# Altium schematic sheet AIM2_v0.9_LTE.SchDoc
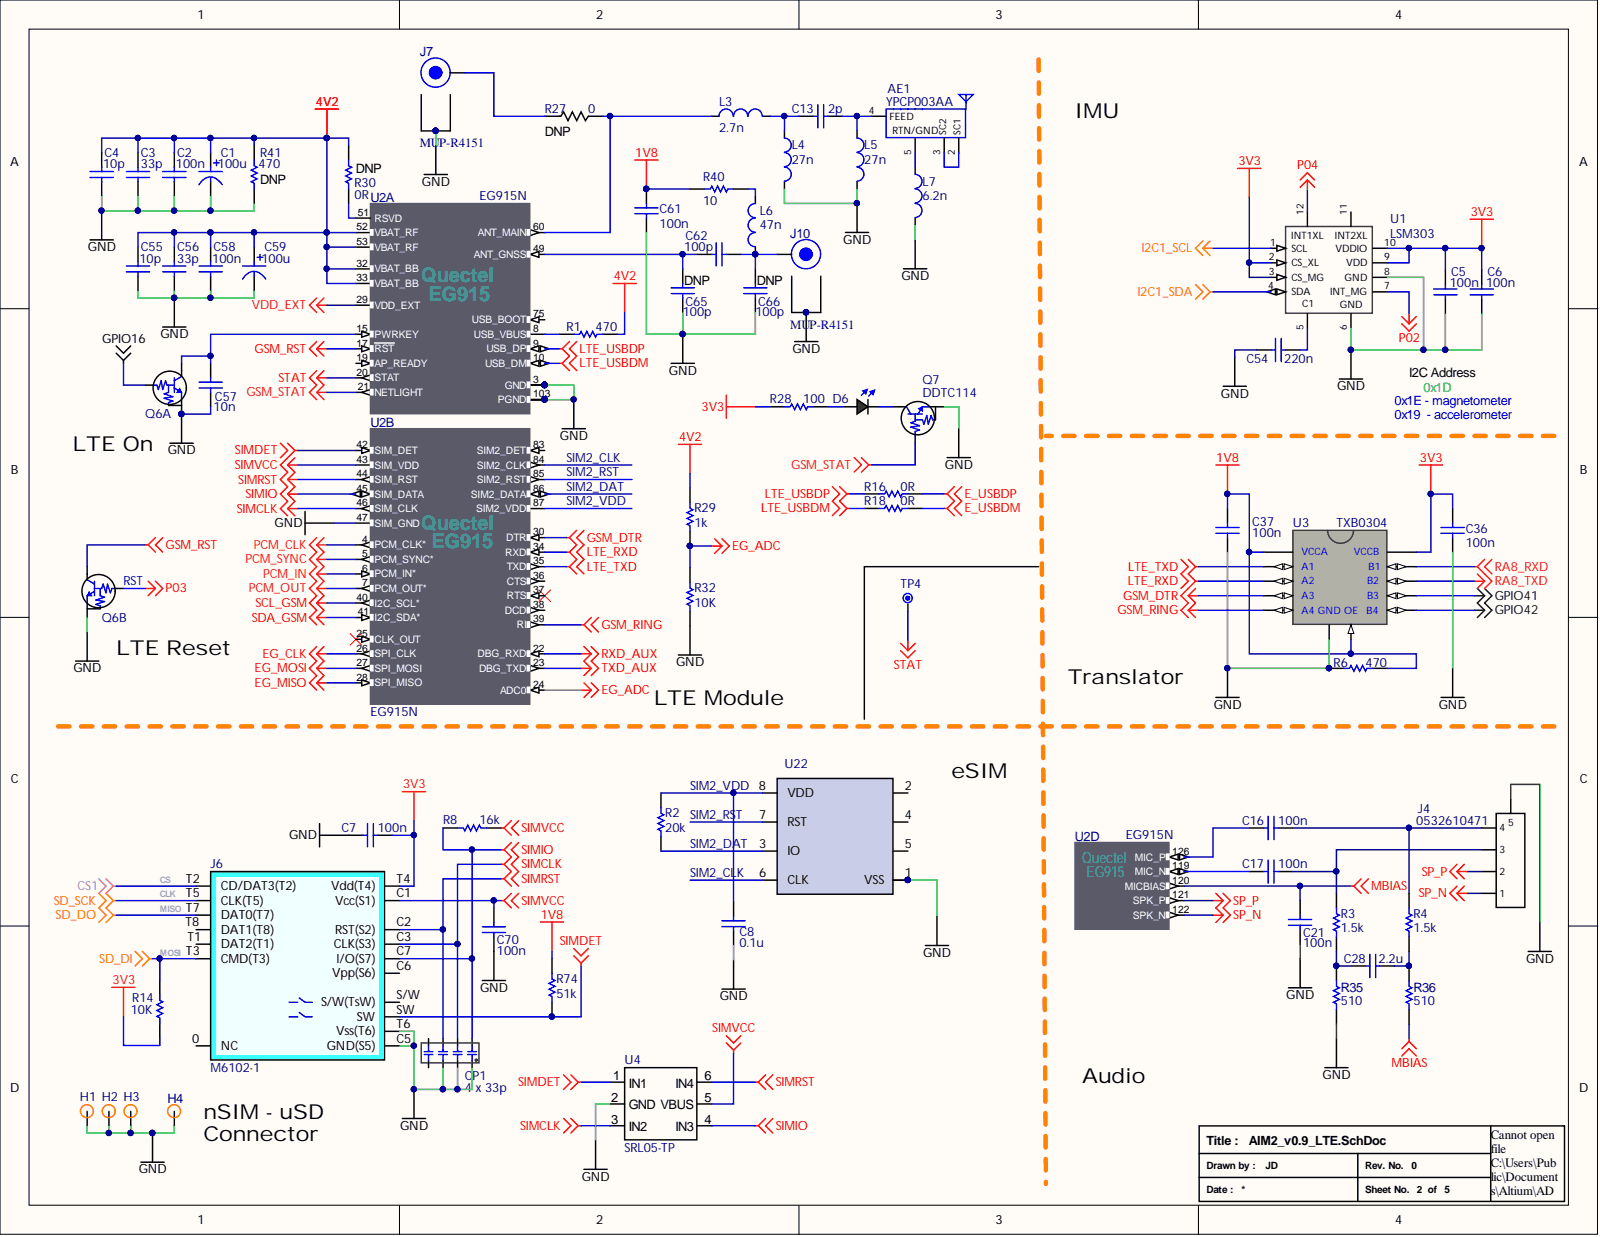
[diagram p0: AIM2_v0.9_LTE.SchDoc]

## Parts
AE1  YPCP003AA  [Quectel_YPCP003AA]
C1  100u  [Cap_1210_TAN]
C2  100n  [CAP_0402]
C3  33p  [CAP_0402]
C4  10p  [CAP_0402]
C5  100n  [CAP_0402]
C6  100n  [CAP_0402]
C7  100n  [CAP_0402]
C8  0.1u  [CAP_0402_ghost]
C13  2p  [CAP_0402]
C16  100n  [CAP_0402_ghost]
C17  100n  [CAP_0402_ghost]
C21  100n  [CAP_0402_ghost]
C28  2.2u  [CAP_0402_ghost]
C36  100n  [CAP_0402]
C37  100n  [CAP_0402]
C54  220n  [CAP_0402]
C55  10p  [CAP_0402]
C56  33p  [CAP_0402]
C57  10n  [CAP_0402]
C58  100n  [CAP_0402]
C59  100u  [Cap_1210_TAN]
C61  100n  [CAP_0402]
C62  100p  [CAP_0402]
C65  100p  [CAP_0402_ghost]
C66  100p  [CAP_0402_ghost]
C70  100n  [CAP_0402]
CP1  4 x 33p  [NCap_0805]
D6  LED_570nm(green), 2.2V,20mA,0603  [LED-0603-GREEN]  (value not drawn)
H1  2.5mm_Via  [2.5_Via]  (value not drawn)
H2  2.5mm_Via  [2.5_Via]  (value not drawn)
H3  2.5mm_Via  [2.5_Via]  (value not drawn)
H4  3.73mm_Hole_6.2mmD  [3.73mm_Hole_6.2mmD]  (value not drawn)
J4  0532610471  [Molex_Pblade_53261-0471_ghost]
J6  M6102-1  [MUP_M6102-1]
J7  MUP-R4151  [UFL_MUP_R4151]
J10  MUP-R4151  [UFL_MUP_R4151]
L3  2.7n  [IND_0402]
L4  27n  [IND_0402]
L5  27n  [IND_0402]
L6  47n  [IND_0402]
L7  6.2n  [IND_0402]
Q6  DMC56404  [SOT_363]  (value not drawn)  (parts A,B)
Q7  DDTC114  [SOT323]
R1  470  [RES_0402]
R2  20k  [RES_0402_ghost]
R3  1.5k  [RES_0402_ghost]
R4  1.5k  [RES_0402_ghost]
R6  470  [RES_0402]
R8  16k  [RES_0402]
R14  10K  [RES_0402]
R16  0R  [RES_0402]
R18  0R  [RES_0402]
R27  0  [RES_0400_ghost]
R28  100  [RES_0402]
R29  1k  [RES_0402]
R30  0R  [RES_0402_ghost]
R32  10K  [RES_0402]
R35  510  [RES_0402_ghost]
R36  510  [RES_0402_ghost]
R40  10  [RES_0402]
R41  470  [RES_0402_ghost]
R74  51k  [RES_0402]
TP4  Testpoint_1mm  [testpoint_1mm]  (value not drawn)
U1  LSM303  [LGA-12_2x2x1]
U2  EG915N  [Quectel_EG915]  (parts A,B,D)
U3  TXB0304  [R_PUQFN_N12_RUT]
U4  SRL05-TP  [SOT23-6L_(2)]
U22  GTO_SIMonChip  [GTO_VQFN_8_ghost]  (value not drawn)

## Nets
1V8: C37.2 C61.2 R6.2 R40.2 R74.1 U3.1(VCCA) U3.12(OE)
3V3: C5.2 C6.2 C7.1 C36.1 J6.T4(Vdd(T4)) R14.1 R28.1 U1.2(CS_XL) U1.3(CS_MG) U1.9(VDD) U1.10(VDDIO) U3.11(VCCB)
4V2: C1.1 C2.2 C3.2 C4.2 C55.2 C56.2 C58.2 C59.1 R1.1 R29.2 R30.2 R41.2 U2.32(VBAT_BB) U2.33(VBAT_BB) U2.52(VBAT_RF) U2.53(VBAT_RF)
CS1: J6.T2(CD/DAT3(T2))
E_USBDM: R18.2
E_USBDP: R16.2
EG_ADC: R29.1 R32.1 U2.24(ADC0)
EG_CLK: U2.26(SPI_CLK)
EG_MISO: U2.28(SPI_MISO)
EG_MOSI: U2.27(SPI_MOSI)
GND: C1.2 C2.1 C3.1 C4.1 C5.1 C6.1 C7.2 C8.2 C21.2 C36.2 C37.1 C54.1 C55.1 C56.1 C57.1 C58.1 C59.2 C61.1 C65.1 C66.1 C70.2 CP1.1 CP1.2 CP1.3 H1.1 H2.1 H3.1 H4.1 J4.5 J6.C5(GND(S5)) J6.T6(Vss(T6)) J7.1 J10.1 L4.2 L5.2 L7.2 Q6.1 Q6.4 Q7.2 R6.1 R32.2 R35.1 R41.1 U1.6 U1.8(GND) U2.3(GND) U2.31 U2.47(SIM_GND) U2.48 U2.50 U2.54 U2.55 U2.58 U2.59 U2.61 U2.62 U2.67 U2.68 U2.69 U2.70 U2.71 U2.72 U2.73 U2.74 U2.79 U2.80 U2.81 U2.82 U2.89 U2.90 U2.91 U2.100 U2.101 U2.102 U2.103(PGND) U2.104 U2.105 U2.106 U3.6 U4.2(GND) U22.1(VSS) U22.9
GPIO16: Q6.2
GPIO41: U3.8(B3)
GPIO42: U3.7(B4)
GSM_DTR: U2.30(DTR) U3.4(A3)
GSM_RING: U2.39(RI) U3.5(A4_GND)
GSM_RST: Q6.3 U2.17(RST)
GSM_STAT: Q7.1 U2.21(NETLIGHT)
I2C1_SCL: U1.1(SCL)
I2C1_SDA: U1.4(SDA)
LTE_RXD: U2.34(RXD) U3.3(A2)
LTE_TXD: U2.35(TXD) U3.2(A1)
LTE_USBDM: R18.1 U2.10(USB_DM)
LTE_USBDP: R16.1 U2.9(USB_DP)
MBIAS: C21.1 R36.1 U2.120(MICBIAS)
P02: U1.7(INT_MG)
P03: Q6.5
P04: U1.12
PCM_CLK: U2.4(PCM_CLK*)
PCM_IN: U2.6(PCM_IN*)
PCM_OUT: U2.7(PCM_OUT*)
PCM_SYNC: U2.5(PCM_SYNC*)
RA8_RXD: U3.10(B1)
RA8_TXD: U3.9(B2)
RXD_AUX: U2.22(DBG_RXD)
SCL_GSM: U2.40(I2C_SCL*)
SD_DI: J6.T3(CMD(T3)) R14.2
SD_DO: J6.T7(DAT0(T7))
SD_SCK: J6.T5(CLK(T5))
SDA_GSM: U2.41(I2C_SDA*)
SIMCLK: CP1.7 J6.C3(CLK(S3)) U2.46(SIM_CLK) U4.3(IN2)
SIMDET: J6.SW R74.2 U2.42(SIM_DET) U4.1(IN1)
SIMIO: CP1.8 J6.C7(I/O(S7)) R8.2 U2.45(SIM_DATA) U4.4(IN3)
SIMRST: CP1.6 J6.C2(RST(S2)) U2.44(SIM_RST) U4.6(IN4)
SIMVCC: C70.1 J6.C1(Vcc(S1)) R8.1 U2.43(SIM_VDD) U4.5(VBUS)
SP_N: J4.1 U2.122(SPK_N)
SP_P: J4.2 U2.121(SPK_P)
STAT: TP4.1 U2.20(STAT)
TXD_AUX: U2.23(DBG_TXD)
VDD_EXT: U2.29(VDD_EXT)
SIM2_CLK: U2.84(SIM2_CLK) U22.6(CLK)
SIM2_DAT: R2.1 U2.86(SIM2_DATA) U22.3(IO)
SIM2_RST: U2.85(SIM2_RST) U22.7(RST)
SIM2_VDD: C8.1 R2.2 U2.87(SIM2_VDD) U22.8(VDD)
NetAE1_2: AE1.2(SC1) AE1.3(SC2)
NetAE1_4: AE1.4(FEED) C13.1 L5.1
NetAE1_5: AE1.5 L7.1
NetC13_2: C13.2 L3.2 L4.1
NetC16_1: C16.1 J4.4 R4.2
NetC16_2: C16.2 U2.126(MIC_P)
NetC17_1: C17.1 J4.3 R3.2
NetC17_2: C17.2 U2.119(MIC_N)
NetC28_1: C28.1 R4.1 R36.2
NetC28_2: C28.2 R3.1 R35.2
NetC54_2: C54.2 U1.5(C1)
NetC57_2: C57.2 Q6.6 U2.15(PWRKEY)
NetC62_1: C62.1 C65.2 U2.49(ANT_GNSS)
NetC62_2: C62.2 C66.2 J10.2 L6.1
NetD6_A: D6.A R28.2
NetD6_K: D6.K Q7.3
NetJ7_2: J7.2 R27.1
NetL3_1: L3.1 U2.60(ANT_MAIN)
NetL6_2: L6.2 R40.1
NetR1_2: R1.2 U2.8(USB_VBUS)
NetR30_1: R30.1 U2.51(RSVD)

Not connected: AE1 1 6 7 8 9; CP1 4 5; J6 0(NC) C6(Vpp(S6)) S/W(S/W(TsW)) T1(DAT2(T1)) T8(DAT1(T8)); U1 11; U2 19(AP_READY) 25(CLK_OUT) 36(CTS) 37(RTS) 38(DCD) 75(USB_BOOT) 83(SIM2_DET); U22 2 4 5

## Library data of the parts
AE1 = Quectel_YPCP003AA: Component Kind: Standard; Library Name: Antenna.SchLib; Supplier 1: Mouser; Supplier Part Number 1: 277-YPCP003AA; Value: YPCP003AA
C1 C59 = Cap_100uF,6.3V,Tan,20%,1210: Component Kind: Standard; Library Name: Cap.SchLib; Supplier 1: Mouser; Supplier Part Number 1: 647-F930J107MBA; Value: 100u
C2 C58 = Cap_0.1uF,10V,10%,X5R,0402: Component Kind: Standard; Library Name: Cap.SchLib; Supplier 1: Mouser; Supplier Part Number 1: 81-GRM155R61A104KA01; Value: 100n
C3 C56 = Cap_33pF,10V,NP0,5%,0402: Component Kind: Standard; Library Name: Cap.SchLib; Supplier 1: Mouser; Supplier Part Number 1: 710-885012005010; Value: 33p
C4 C55 = Cap_10pF,50V,C0G,1%,0402: Component Kind: Standard; Library Name: Cap.SchLib; Supplier 1: Mouser; Supplier Part Number 1: 81-GRM1555C1H100FA1D; Value: 10p
C5-C7 C16 C17 C21 C36 C37 C61 C70 = Cap_100nF,50V,X5R,10%,0402: Component Kind: Standard; Library Name: Cap.SchLib; Supplier 1: Mouser; Supplier Part Number 1: 81-GRM155R61H104KE4D; Value: 100n
C8 = Cap_0.1uF,6.3V,10%,X5R,0402: Component Kind: Standard; Library Name: Cap.SchLib; Supplier 1: Mouser; Supplier Part Number 1: 81-GRM155R60J104KA1D; Value: 0.1u
C13 = Cap_2pF,50V,C0G,0.05pF,0402: Component Kind: Standard; Library Name: Cap.SchLib; Supplier 1: Mouser; Supplier Part Number 1: 81-GRM1555C1H2R0WA1D; Value: 2p
C28 = Cap_2.2uF,10V,10%,X5R,0402: Component Kind: Standard; Library Name: Cap.SchLib; Supplier 1: Mouser; Supplier Part Number 1: 81-GRM155R61A225KE5D; Value: 2.2u
C54 = Cap_220nF,10V,X5R,10%,0402: Component Kind: Standard; Library Name: Cap.SchLib; Supplier 1: Mouser; Supplier Part Number 1: 81-GRM152R61A224KE9D; Value: 220n
C57 = Cap_10nF,16V,X7R,10%,0402: Component Kind: Standard; Library Name: Cap.SchLib; Supplier 1: Mouser; Supplier Part Number 1: 81-GRM36X103K16; Value: 10n
C62 C65 C66 = Cap_100pF,10V,C0G,2%,0402: Component Kind: Standard; Library Name: Cap.SchLib; Supplier 1: Mouser; Supplier Part Number 1: 791-0402N101G100CT; Value: 100p
CP1 = NCap_33pF,10%,0508: Component Kind: Standard; Library Name: Cap.SchLib; Supplier 1: Mouser; Supplier Part Number 1: 581-W2A41A330KAT2A; Value: 4 x 33p
J4 = Molex_pblade_0532610471: Component Kind: Standard; Library Name: SW.SchLib; Supplier 1: Mouser; Supplier Part Number 1: 538-53261-0471; Value: 0532610471
J6 = MUP-M6102-1: micro SD+Nano SIM connector push pull type 2 in 1 connector for card reader; Component Kind: Standard; Library Name: SW.SchLib; Value: M6102-1
J7 J10 = UFL_R4151: Component Kind: Standard; Library Name: SW.SchLib; Value: MUP-R4151
L3 = Ind_2.7nH,850mA,50mOhm,0.1nH,0402: Component Kind: Standard; Library Name: Inductor.SchLib; Supplier 1: Mouser; Supplier Part Number 1: 81-LQW15AN2N7B00D; Value: 2.7n
L4 L5 = Ind_27nH,350mA,460mOhm,5%,0402: Component Kind: Standard; Library Name: Inductor.SchLib; Supplier 1: Mouser; Supplier Part Number 1: 81-LQG15HS27NJ02D; Value: 27n
L6 = Ind_47nH,210mA,1.8Ohms,2%,0402: Component Kind: Standard; Library Name: Inductor.SchLib; Supplier 1: Mouser; Supplier Part Number 1: 81-LQW15AN47NG00D; Value: 47n
L7 = Ind_6.2nH,700mA,70mOhm,0.1nH,0402: Component Kind: Standard; Library Name: Inductor.SchLib; Supplier 1: Mouser; Supplier Part Number 1: 81-LQW15AN6N2B00D; Value: 6.2n
Q6 = BJT_NPN,10kOhms,VCEO50V,Dual: Component Kind: Standard; Library Name: Trans.SchLib; Supplier 1: Mouser; Supplier Part number 1: 667-DMC564040R; Value: DMC56404
Q7 = BJT_NPN_10kOhm,200mW: Component Kind: Standard; Library Name: Trans.SchLib; Supplier 1: Mouser; Supplier Part Number 1: 621-DDTC114YUA-F; Value: DDTC114
R1 R6 R41 = Res_470R,1%,100mW,0402: Component Kind: Standard; Library Name: RES.SchLib; Supplier 1: Mouser; Supplier Part Number 1: 667-ERJ-2RKF4700X; Value: 470
R2 = Res_20k,1%,1/16W,0402: Component Kind: Standard; Library Name: RES.SchLib; Supplier 1: Mouser; Supplier Part Number 1: 71-CRCW0402-20K-E3; Value: 20k
R3 R4 = Res_1.5k,1%,62.5W,0402: Component Kind: Standard; Library Name: RES.SchLib; Supplier 1: Mouser; Supplier Part Number 1: 603-RC0402FR-071K5L; Value: 1.5k
R8 = Res_16k,1%,62.5mW,0402: Component Kind: Standard; Library Name: RES.SchLib; Supplier 1: Mouser; Supplier Part Number 1: 603-RC0402FR-0716KL; Value: 16k
R14 R32 = Res_10K, 1%,1/16W,0402: Component Kind: Standard; Library Name: RES.SchLib; Supplier 1: Mouser; Supplier Part Number 1: 603-RC0402FR-0710KL; Value: 10K
R16 R18 R30 = Res_0R,1%,1/16W, 0402: Component Kind: Standard; Library Name: RES.SchLib; Supplier 1: Mouser; Supplier Part Number 1: 603-RC0402JR-070RL; Value: 0R
R27 = Res_0R,0400: Component Kind: Standard; Library Name: RES.SchLib; Value: 0
R28 = Res_100R,5%,100mW,0402: Component Kind: Standard; Library Name: RES.SchLib; Supplier 1: Mouser; Supplier Part Number 1: 603-RC0402FR-07100RL; Value: 100
R29 = Res_1K, 1%,1/16 W,0402: Component Kind: Standard; Library Name: RES.SchLib; Supplier 1: Mouser; Supplier Part Number 1: 603-RC0402FR-071KL; Value: 1k
R35 R36 = Res_510R,1%,1/16W,0402: Component Kind: Standard; Library Name: RES.SchLib; Value: 510
R40 = Res_10R,1%,100mW,0402: Component Kind: Standard; Library Name: RES.SchLib; Supplier 1: Mouser; Supplier Part Number 1: 667-ERJ-2RKF10R0X; Value: 10
R74 = Res_51K,1%,1/16W,0402: Component Kind: Standard; Library Name: RES.SchLib; Supplier 1: Mouser; Supplier Part Number 1: 603-RC0402FR-0751KL; Value: 51k
U1 = LSM303AGRTR: Component Kind: Standard; Library Name: ICs.SchLib; Supplier 1: Mouser; Supplier Part Number 1: 511-LSM303AGRTR; Value: LSM303
U2 = Quectel_EG915N: Component Kind: Standard; Library Name: ICs.SchLib; Value: EG915N
U3 = TXB0304RUTR: Component Kind: Standard; Library Name: ICs.SchLib; Supplier 1: Mouser; Supplier Part Number 1: 595-TXB0304RUTR; Value: TXB0304
U4 = ESD_SRL05-TP: Component Kind: Standard; Library Name: Diode.SchLib; Supplier 1: Mouser; Supplier Part Number 1: 833-SRL05-TP; Value: SRL05-TP
U22 = GTO_SIMonChip: Value: ST4SIM-110A; Component Kind: Standard; Library Name: ICs.SchLib
D6 = LED_570nm(green), 2.2V,20mA,0603: Component Kind: Standard; Library Name: Diode.SchLib; Supplier 1: Mouser; Supplier Part Number 1: 859-LTST-C194KGKT
H1-H3 = 2.5mm_Via: Component Kind: Standard; Library Name: SW.SchLib
H4 = 3.73mm_Hole_6.2mmD: Component Kind: Standard; Library Name: SW.SchLib
TP4 = Testpoint_1mm: Component Kind: Standard; Library Name: SW.SchLib
